# Altium schematic sheet STM32_AVR_demoboard_3.SchDoc(STM32_AVR_demoboard_3)
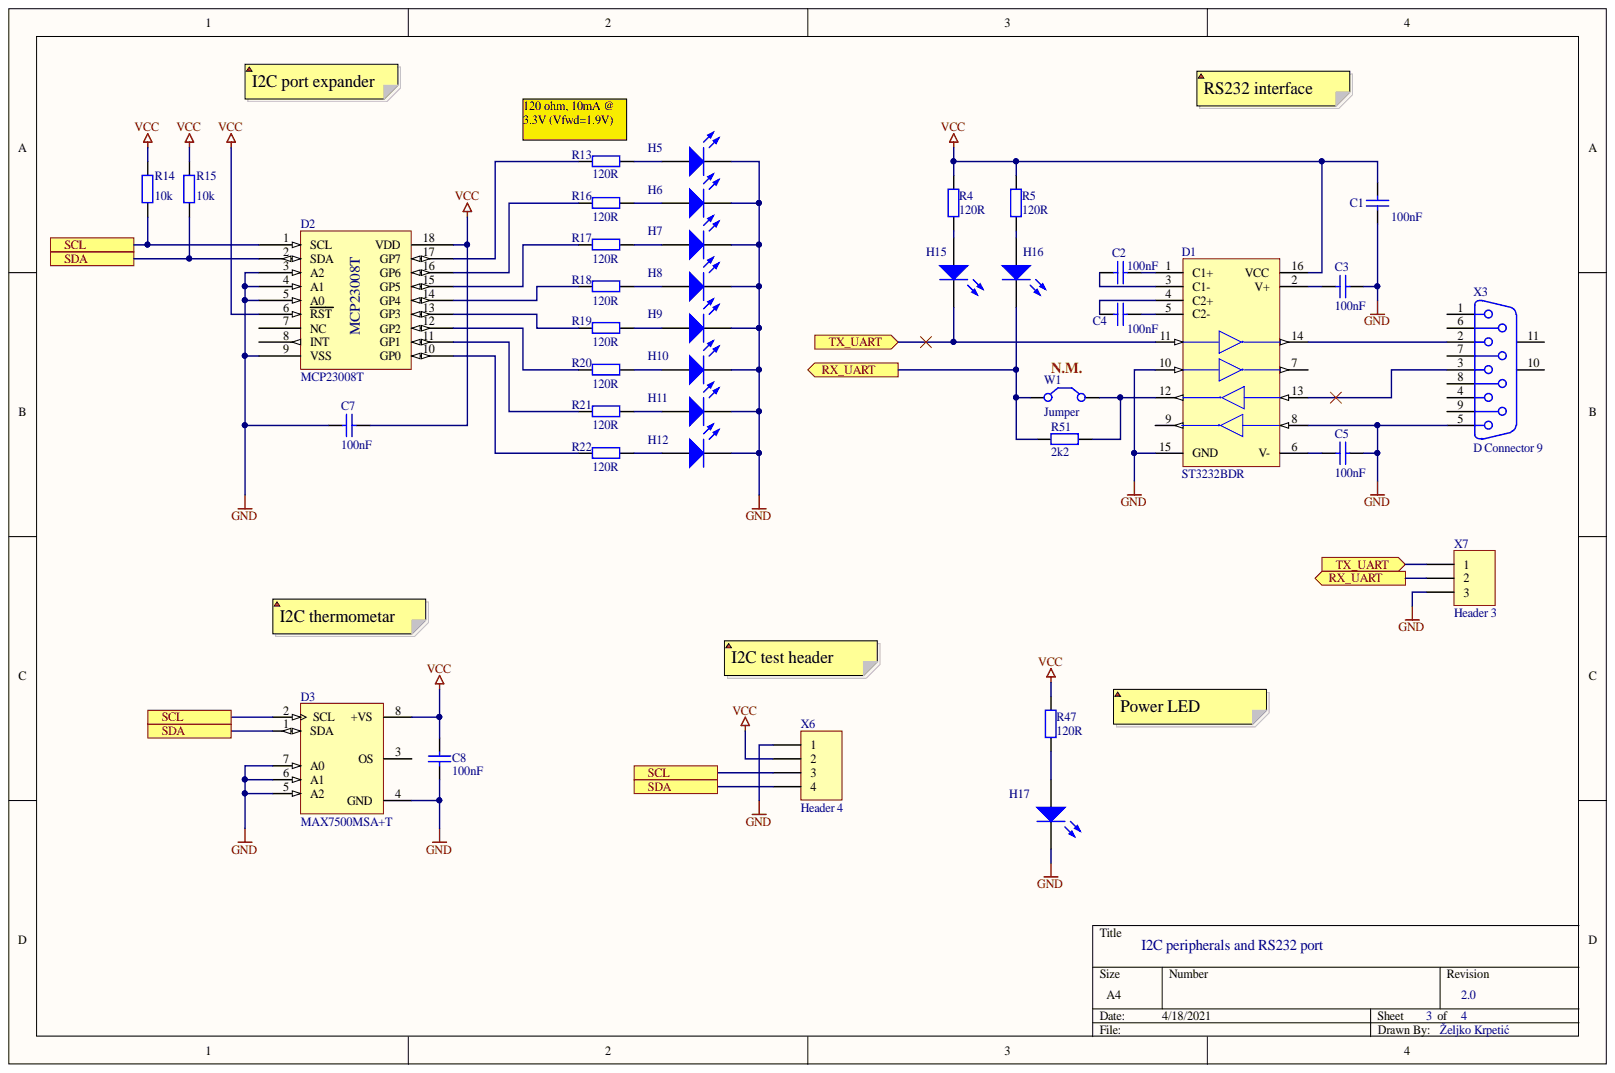
[diagram p0: STM32_AVR_demoboard_3.SchDoc]

## Parts
C1  100nF  [ERA-0805]
C2  100nF  [ERA-0805]
C3  100nF  [ERA-0805]
C4  100nF  [ERA-0805]
C5  100nF  [ERA-0805]
C7  100nF  [ERA-0805]
C8  100nF  [ERA-0805]
D1  ST3232BDR  [NSO16_N]
D2  MCP23008T
D3  MAX7500MSA+T  [MAXM-S8+2_N]
H5  LED RED  [LED]  (value not drawn)
H6  LED RED  [LED]  (value not drawn)
H7  LED RED  [LED]  (value not drawn)
H8  LED RED  [LED]  (value not drawn)
H9  LED RED  [LED]  (value not drawn)
H10  LED RED  [LED]  (value not drawn)
H11  LED RED  [LED]  (value not drawn)
H12  LED RED  [LED]  (value not drawn)
H15  LED RED  [LED]  (value not drawn)
H16  LED RED  [LED]  (value not drawn)
H17  LED RED  [LED]  (value not drawn)
R4  value 120R  [ERA-0805]  (hidden comment: Resistor)
R5  value 120R  [ERA-0805]  (hidden comment: Resistor)
R13  value 120R  [ERA-0805]  (hidden comment: Resistor)
R14  value 10k  [ERA-0805]  (hidden comment: Resistor)
R15  value 10k  [ERA-0805]  (hidden comment: Resistor)
R16  value 120R  [ERA-0805]  (hidden comment: Resistor)
R17  value 120R  [ERA-0805]  (hidden comment: Resistor)
R18  value 120R  [ERA-0805]  (hidden comment: Resistor)
R19  value 120R  [ERA-0805]  (hidden comment: Resistor)
R20  value 120R  [ERA-0805]  (hidden comment: Resistor)
R21  value 120R  [ERA-0805]  (hidden comment: Resistor)
R22  value 120R  [ERA-0805]  (hidden comment: Resistor)
R47  value 120R  [ERA-0805]  (hidden comment: Resistor)
R51  value 2k2  [ERA-0805]  (hidden comment: Resistor)
W1  Jumper  [HDR1X2]
X3  D Connector 9  [DSUB1.385-2H9]
X6  Header 4  [HDR1X4]
X7  Header 3  [HDR1X3]

## Ports
RX_UART, SCL, SDA, TX_UART

## Nets
GND: C1.1 C3.1 C5.1 C7.2 C8.2 D1.8 D1.10 D1.15 D2.3 D2.4 D2.5 D2.9 D3.4 D3.5 D3.6 D3.7 H5.2 H6.2 H7.2 H8.2 H9.2 H10.2 H11.2 H12.2 H17.2 X3.5 X6.1 X7.3
VCC: C1.2 C7.1 C8.1 D1.16 D2.6 D2.18 D3.8 R4.1 R5.1 R14.1 R15.1 R47.1 X6.2
RX_UART: H16.2 R51.1 W1.1 X7.2 ; port RX_UART
SCL: D2.1 D3.2 R14.2 X6.3 ; port SCL
SDA: D2.2 D3.1 R15.2 X6.4 ; port SDA
TX_UART: D1.11 H15.2 X7.1 ; port TX_UART
NetC2_1: C2.1 D1.1
NetC2_2: C2.2 D1.3
NetC3_2: C3.2 D1.2
NetC4_1: C4.1 D1.5
NetC4_2: C4.2 D1.4
NetC5_2: C5.2 D1.6
NetD1_12: D1.12 R51.2 W1.2
NetD1_13: D1.13 X3.3
NetD1_14: D1.14 X3.2
NetD2_10: D2.10 R22.2
NetD2_11: D2.11 R21.2
NetD2_12: D2.12 R20.2
NetD2_13: D2.13 R19.2
NetD2_14: D2.14 R18.2
NetD2_15: D2.15 R17.2
NetD2_16: D2.16 R16.2
NetD2_17: D2.17 R13.2
NetH5_1: H5.1 R13.1
NetH6_1: H6.1 R16.1
NetH7_1: H7.1 R17.1
NetH8_1: H8.1 R18.1
NetH9_1: H9.1 R19.1
NetH10_1: H10.1 R20.1
NetH11_1: H11.1 R21.1
NetH12_1: H12.1 R22.1
NetH15_1: H15.1 R4.2
NetH16_1: H16.1 R5.2
NetH17_1: H17.1 R47.2

Not connected: D1 7 9; D2 7 8; D3 3; X3 1 4 6 7 8 9 10 11

## Library data of the parts
C1-C5 C7 C8 = Cap Semi: Capacitor (Semiconductor SIM Model); Value: 100nF; Simulation: CAP; Signal Integrity: Cap Semi; Library Name: Miscellaneous Devices.IntLib; Farnell order code: 2320839; Component Kind: Standard
  C1-C5: Published: 8-Jun-2000; PackageReference: C1206; PackageDescription: Chip Capacitor; LatestRevisionNote: IPC-7351 Footprint Added.; LatestRevisionDate: 29-May-2009
  C8: Published: 8-Jun-2000; LatestRevisionNote: IPC-7351 Footprint Added.; LatestRevisionDate: 29-May-2009
  C1-C5 C8: Publisher: Altium Limited
D1 = ST3232BDR: 3.0V TO 5.5V, RS-232 Transceiver; PCB3D: NSO16; Farnell Order Code: 1467752; Component Kind: Standard; Library Name: FER projekt schLib.SchLib
D2 = MCP23008: 8 bit i2C port expander; Component Kind: Standard; Library Name: FER projekt PCB.SCHLIB
D3 = MAX7500MSA+T: Digital Temperature Sensor with Bus Lock-up Protection, -55 to 125 degC, 8-Pin SOIC (S8+2), Pb-Free, Tape and Reel; Parasite Pwr.: No; Multi Droppable: Yes; Interface: 2-Wire/I2C/SMBus; PartNumber: MAX7500MSA+T; Packing: Tape and Reel; PackageVersion: Rev. D, 10/2012; PackageReference: S8+2; PackageDescription: 8-Pin Small-Outline IC, Narrow (0.15in) (S8+2), Body 4.90 x 3.90 mm, Pitch 1.27 mm; Mounting Technology: Surface Mount; Generic Part Number: MAX7500; Farnell order code: 2515642; DatasheetVersion: Rev. 4; ComponentLink3URL: http://pdfserv.maximintegrated.com/package_dwgs/21-0041.PDF; ComponentLink3Description: Package Specification; ComponentLink2URL: http://datasheets.maximintegrated.com/en/ds/MAX7500-MAX7504.pdf; ComponentLink2Description: Datasheet; ComponentLink1URL: http://www.maximintegrated.com/; ComponentLink1Description: Manufacturer URL; Component Kind: Standard; Code_JEDEC: MS012; Library Name: FER projekt schLib.SchLib
H5-H12 H15-H17 = LED: Farnell order code: 8529930; Component Kind: Standard; Library Name: FER projekt schLib.SchLib
W1 = Jumper: Jumper Wire; Published: 24-Mar-1999; PackageReference: RAD-0.2; PackageDescription: Radial Cap, Thru-Hole; 2 Leads; 0.2 in Pin Spacing; Library Name: Miscellaneous Devices.IntLib; LatestRevisionNote: Re-released for DXP Platform.; LatestRevisionDate: 17-Jul-2002; Component Kind: Standard; Publisher: Altium Limited
X3 = D Connector 9: Receptacle Assembly, 9 Position, Right Angle; Positions: 9; PackageDocument: O; Signal Integrity: Connector; Published: 15-Jun-2001; PackageReference: 788750; Orientation: Right Angle; Mouser: 571-2311765-1; Library Name: Miscellaneous Connectors.IntLib; LatestRevisionNote: Re-released for DXP Platform.; LatestRevisionDate: 17-Jul-2002; Gender: Receptacle; DatasheetDocument: 1-Sep-1999; Component Kind: Standard; Publisher: Altium Limited
X6 = Header 4: Header, 4-Pin; Signal Integrity: Connector; Library Name: Miscellaneous Connectors.IntLib; LatestRevisionNote: Re-released for DXP Platform.; LatestRevisionDate: 17-Jul-2002; Component Kind: Standard; Publisher: Altium Limited
X7 = Header 3: Header, 3-Pin; Signal Integrity: Connector; Library Name: Miscellaneous Connectors.IntLib; LatestRevisionNote: Re-released for DXP Platform.; LatestRevisionDate: 17-Jul-2002; Component Kind: Standard; Publisher: Altium Limited
R4 R5 R13-R22 R47 R51 = Resistor: Component Kind: Standard; Library Name: FER projekt schLib.SchLib
  R4 R5 R13 R16-R22 R47: Farnell order code: 2447561
  R14 R15: Farnell order code: 2073607
  R51: Farnell order code: 2447640
